# Altium schematic sheet NXP NXPhlite-MCU 3.0RC18.sch
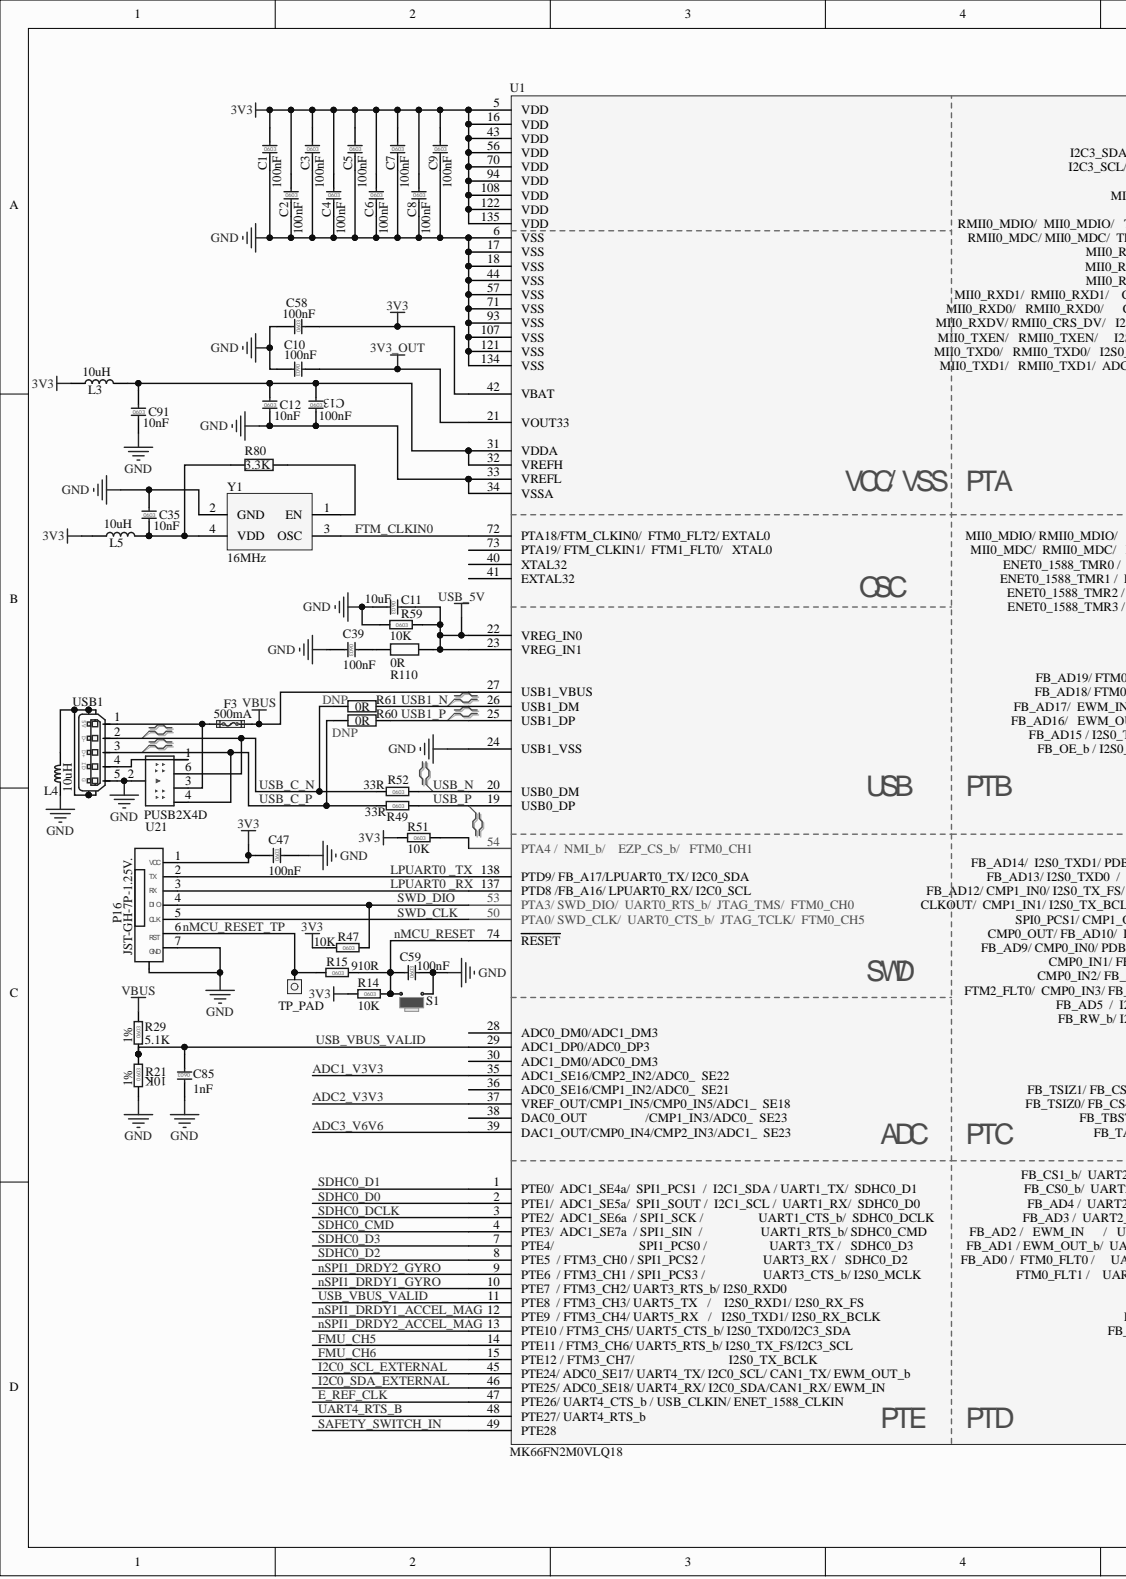
[diagram p0: NXP NXPhlite-MCU 3.0RC18.sch, left]
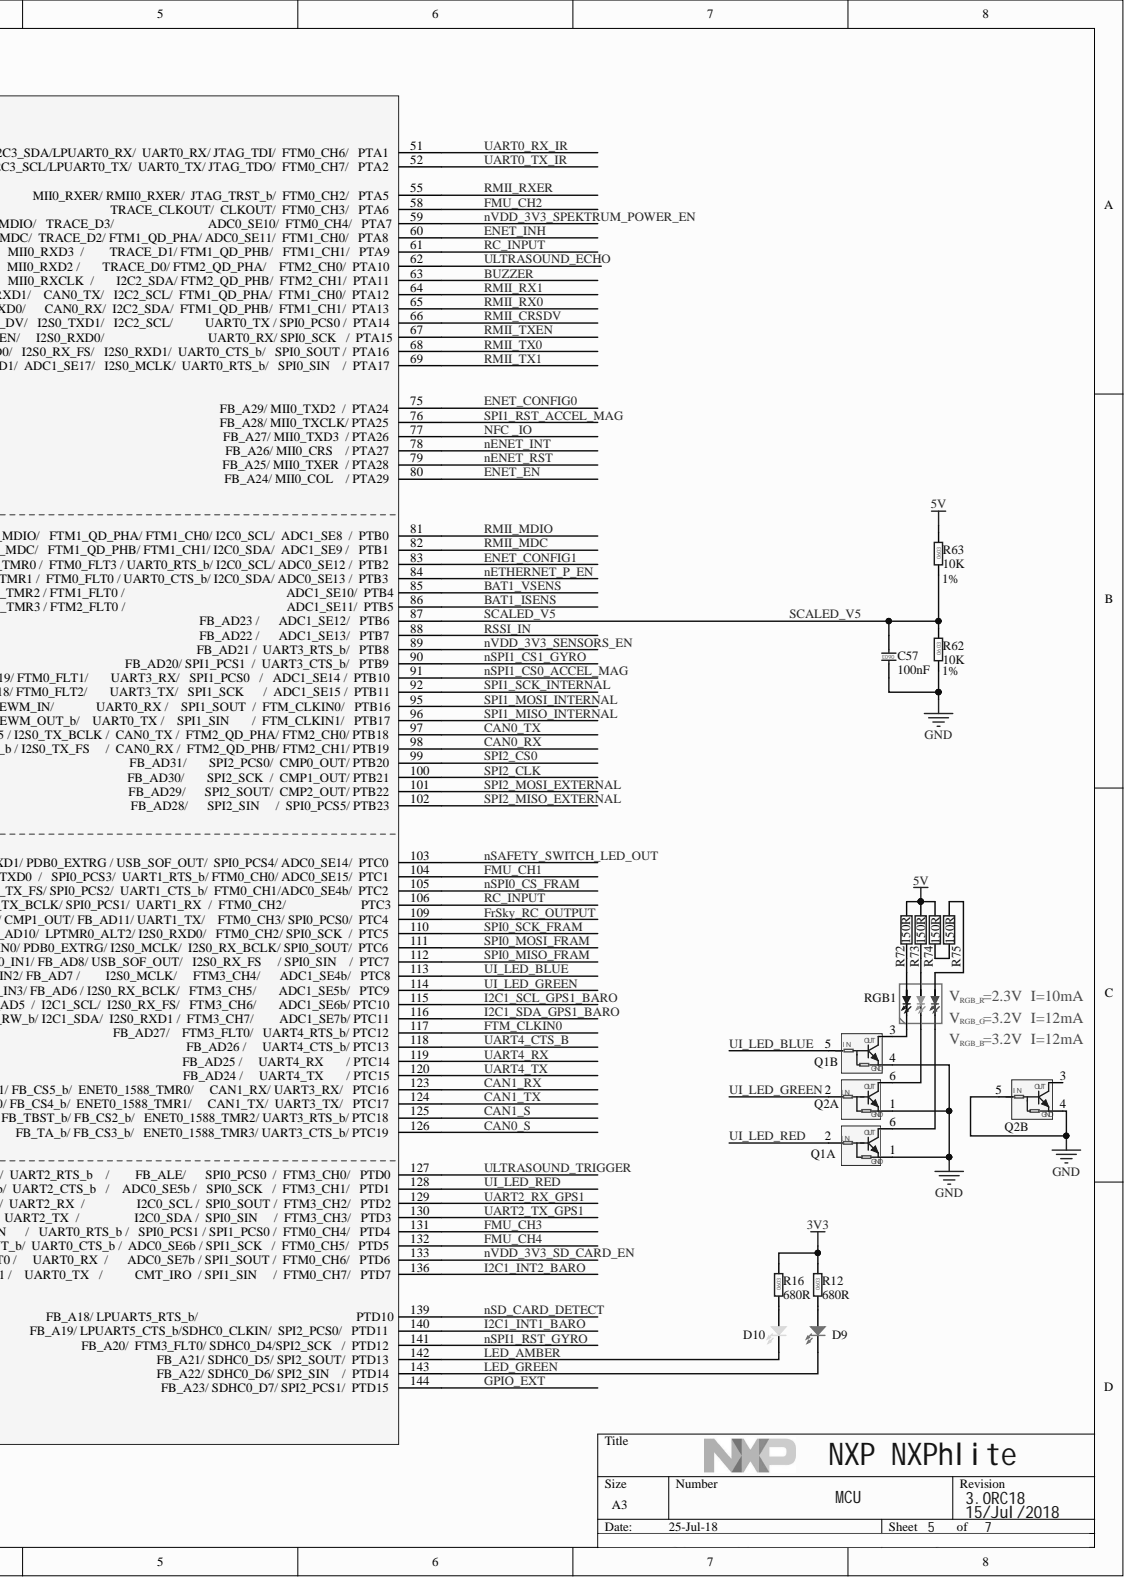
[diagram p1: NXP NXPhlite-MCU 3.0RC18.sch, right]

## Parts
C1  100nF  [0603C]
C2  100nF  [0603C]
C3  100nF  [0603C]
C4  100nF  [0603C]
C5  100nF  [0603C]
C6  100nF  [0603C]
C7  100nF  [0603C]
C8  100nF  [0603C]
C9  100nF  [0603C]
C10  100nF  [0603C]
C11  10uF  [0603C]
C12  10nF  [0603C]
C13  100nF  [0603C]
C35  10nF  [0603C]
C39  100nF  [0603C]
C47  100nF  [0603C]
C57  100nF  [0603C]
C58  100nF  [0603C]
C59  100nF  [0603C]
C85  1nF  [0603C]
C91  10nF  [0603C]
D9  LED G  [0603LED_ÂÌ]  (value not drawn)
D10  LED A  [0603LED_Amber]  (value not drawn)
F3  500mA  [0805FUSE]
L3  10uH  [0603(1608)]
L4  10uH  [0603L]
L5  10uH  [0603(1608)]
P16  JST-GH-7P-1.25V.  [JST-7P-1.25]
Q1  UMH11N  [SOT-363]  (value not drawn)  (parts A,B)
Q2  UMH11N  [SOT-363]  (value not drawn)  (parts A,B)
R12  680R  [0603R]
R14  10K  [0603R]
R15  910R  [0603R]
R16  680R  [0603R]
R21  10K  [0603R]  (value not drawn)
R29  5.1K  [0603R]
R47  10K  [0603R]
R49  33R  [0603R]
R51  10K  [0603R]
R52  33R  [0603R]
R59  10K  [0603R]
R60  0R  [0603R]
R61  0R  [0603R]
R62  10K  [0603R]
R63  10K  [0603R]
R72  150R  [0603R]
R73  150R  [0603R]
R74  150R  [0603R]
R75  150R  [0603R]
R80  3.3K  [0603R]
R110  0R  [0603R]
RGB1  5050RGB-NJ  (value not drawn)
S1  EVQP7J01K  [SW]  (value not drawn)
TP_PAD  TP  [TP_0.8]
U1  MK66FN2M0VLQ18  [LQFP144(0.5P -22X22)]
U21  PUSB2X4D  [SOT-23-6(SOT457)]
USB1  47589-0001  [USB]  (value not drawn)
Y1  16MHz  [QX333A16.00000B15M]

## Nets
3V3: C1.1 C2.1 C3.1 C4.1 C5.1 C6.1 C7.1 C8.1 C9.1 C47.1 C58.2 L3.1 L5.1 P16.1(VCC) R12.1 R14.2 R16.1 R47.2 R51.2 U1.5(VDD) U1.16(VDD) U1.42(VBAT) U1.43(VDD) U1.56(VDD) U1.70(VDD) U1.94(VDD) U1.108(VDD) U1.122(VDD) U1.135(VDD)
3V3_OUT: C10.1 U1.21(VOUT33)
5V: R63.1 R72.1 R73.1 R74.1
GND: C1.2 C2.2 C3.2 C4.2 C5.2 C6.2 C7.2 C8.2 C9.2 C10.2 C11.2 C12.2 C13.2 C35.1 C39.2 C47.2 C57.1 C58.1 C59.2 C85.1 C91.2 L4.1 P16.7 Q1.1 Q1.4 Q2.1 Q2.4 Q2.5 R21.2 R59.2 R62.2 S1.2 U1.6(VSS) U1.17(VSS) U1.18(VSS) U1.24(USB1_VSS) U1.33(VREFL) U1.34(VSSA) U1.44(VSS) U1.57(VSS) U1.71(VSS) U1.93(VSS) U1.107(VSS) U1.121(VSS) U1.134(VSS) U21.2 USB1.5 Y1.2(GND)
USB_5V: C11.1 R59.1 R110.1 U1.22(VREG_IN0) U1.23(VREG_IN1)
VBUS: F3.2 R29.1 U1.27(USB1_VBUS)
ADC1_V3V3: U1.35(ADC1_SE16/CMP2_IN2/ADC0_)
ADC2_V3V3: U1.37(VREF_OUT/CMP1_IN5/CMP0_IN5/ADC1_)
ADC3_V6V6: U1.39(DAC1_OUT/CMP0_IN4/CMP2_IN3/ADC1_)
BAT1_ISENS: U1.86(PTB5)
BAT1_VSENS: U1.85(PTB4)
BUZZER: U1.63(PTA11)
CAN0_RX: U1.98(FTM2_QD_PHB/_FTM2_CH1/_PTB19)
CAN0_S: U1.126(ENET0_1588_TMR3/_UART3_CTS_b/_PTC19)
CAN0_TX: U1.97(FTM2_QD_PHA/_FTM2_CH0/_PTB18)
CAN1_RX: U1.123(PTC16)
CAN1_S: U1.125(ENET0_1588_TMR2/_UART3_RTS_b/_PTC18)
CAN1_TX: U1.124(PTC17)
E_REF_CLK: U1.47(PTE26/_UART4_CTS_b_/_USB_CLKIN/_ENET_1588_CLKIN)
ENET_CONFIG0: U1.75(PTA24)
ENET_CONFIG1: U1.83(PTB2)
ENET_EN: U1.80(/_PTA29)
ENET_INH: U1.60(PTA8)
FMU_CH1: U1.104(PTC1)
FMU_CH2: U1.58(PTA6)
FMU_CH3: U1.131(PTD4)
FMU_CH4: U1.132(PTD5)
FMU_CH5: U1.14(PTE11_/_FTM3_CH6/_UART5_RTS_b/_I2S0_TX_FS/I2C3_SCL)
FMU_CH6: U1.15(PTE12_/_FTM3_CH7/)
FrSky_RC_OUTPUT: U1.109(PTC4)
FTM_CLKIN0: U1.72(PTA18/FTM_CLKIN0/) U1.117(UART4_RTS_b/_PTC12) Y1.3(OSC)
GPIO_EXT: U1.144(PTD15)
I2C0_SCL_EXTERNAL: U1.45(PTE24/_ADC0_SE17/_UART4_TX/_I2C0_SCL/_CAN1_TX/_EWM_OUT_b)
I2C0_SDA_EXTERNAL: U1.46(PTE25/_ADC0_SE18/_UART4_RX/_I2C0_SDA/CAN1_RX/_EWM_IN)
I2C1_INT1_BARO: U1.140(PTD11)
I2C1_INT2_BARO: U1.136(PTD7)
I2C1_SCL_GPS1_BARO: U1.115(ADC1_SE6b/_PTC10)
I2C1_SDA_GPS1_BARO: U1.116(ADC1_SE7b/_PTC11)
LED_AMBER: D10.2 U1.142(PTD13)
LED_GREEN: D9.2 U1.143(PTD14)
LPUART0 _RX: P16.3(RX) U1.137(PTD8_/FB_A16/_LPUART0_RX/_I2C0_SCL)
LPUART0 _TX: P16.2(TX) U1.138(PTD9/_FB_A17/LPUART0_TX/_I2C0_SDA)
nENET_INT: U1.78(/_PTA27)
nENET_RST: U1.79(/_PTA28)
nETHERNET_P_EN: U1.84(PTB3)
NFC _IO: U1.77(/_PTA26)
nMCU_RESET: C59.1 R14.1 R15.1 S1.1 U1.74(RESET)
nMCU_RESET_TP: P16.6 R15.2 TP_PAD.1
nSAFETY_SWITCH_LED_OUT: U1.103(PTC0)
nSD_CARD_DETECT: U1.139(PTD10)
nSPI0_CS_FRAM: U1.105(PTC2)
nSPI1_CS0_ACCEL_MAG: U1.91(PTB10)
nSPI1_CS1_GYRO: U1.90(PTB9)
nSPI1_DRDY1_ACCEL_MAG: U1.12(PTE9)
nSPI1_DRDY1_GYRO: U1.10(PTE7)
nSPI1_DRDY2_ACCEL_MAG: U1.13(PTE10_/_FTM3_CH5/_UART5_CTS_b/_I2S0_TXD0/I2C3_SDA)
nSPI1_DRDY2_GYRO: U1.9(PTE6)
nSPI1_RST_GYRO: U1.141(PTD12)
nVDD_3V3_SD_CARD_EN: U1.133(PTD6)
nVDD_3V3_SENSORS_EN: U1.89(PTB8)
nVDD_3V3_SPEKTRUM_POWER_EN: U1.59(PTA7)
RC_INPUT: U1.61(PTA9) U1.106(PTC3)
RMII_CRSDV: U1.66(PTA14)
RMII_MDC: U1.82(PTB1)
RMII_MDIO: U1.81(PTB0)
RMII_RX0: U1.65(PTA13)
RMII_RX1: U1.64(PTA12)
RMII_RXER: U1.55(PTA5)
RMII_TX0: U1.68(PTA16)
RMII_TX1: U1.69(PTA17)
RMII_TXEN: U1.67(PTA15)
RSSI_IN: U1.88(PTB7)
SAFETY_SWITCH_IN: U1.49(PTE28)
SCALED_V5: C57.2 R62.1 R63.2 U1.87(PTB6)
SDHC0_CMD: U1.4(PTE3/)
SDHC0_D0: U1.2(PTE1/)
SDHC0_D1: U1.1(PTE0/)
SDHC0_D2: U1.8(PTE5)
SDHC0_D3: U1.7(PTE4/)
SDHC0_DCLK: U1.3(PTE2/)
SPI0_MISO_FRAM: U1.112(PTC7)
SPI0_MOSI_FRAM: U1.111(PTC6)
SPI0_SCK_FRAM: U1.110(PTC5)
SPI1_MISO_INTERNAL: U1.96(PTB17)
SPI1_MOSI_INTERNAL: U1.95(PTB16)
SPI1_RST_ACCEL_MAG: U1.76(FB_A28/_MII0_TXCLK/_PTA25)
SPI1_SCK_INTERNAL: U1.92(PTB11)
SPI2_CLK: U1.100(CMP1_OUT/_PTB21)
SPI2_CS0: U1.99(CMP0_OUT/_PTB20)
SPI2_MISO_EXTERNAL: U1.102(SPI0_PCS5/_PTB23)
SPI2_MOSI_EXTERNAL: U1.101(CMP2_OUT/_PTB22)
SWD_CLK: P16.5(CLK) U1.50(PTA0/_SWD_CLK/)
SWD_DIO: P16.4(DI_O) R47.1 U1.53(PTA3/_SWD_DIO/)
UART0_RX_IR: U1.51(PTA1)
UART0_TX_IR: U1.52(PTA2)
UART2_RX_GPS1: U1.129(PTD2)
UART2_TX_GPS1: U1.130(PTD3)
UART4_CTS_B: U1.118(UART4_CTS_b/_PTC13)
UART4_RTS_B: U1.48(PTE27/_UART4_RTS_b)
UART4_RX: U1.119(/_PTC14)
UART4_TX: U1.120(/_PTC15)
UI_LED_BLUE: Q1.5 U1.113(PTC8)
UI_LED_GREEN: Q2.2 U1.114(PTC9)
UI_LED_RED: Q1.2 U1.128(PTD1)
ULTRASOUND_ECHO: U1.62(PTA10)
ULTRASOUND_TRIGGER: U1.127(PTD0)
USB1_N: R61.1 U1.26(USB1_DM)
USB1_P: R60.1 U1.25(USB1_DP)
USB_C_N: R52.2 R61.2 U21.6 USB1.2(D-)
USB_C_P: R49.2 R60.2 U21.4 USB1.3(D+)
USB_N: R52.1 U1.20(USB0_DM)
USB_P: R49.1 U1.19(USB0_DP)
USB_VBUS_VALID: C85.2 R21.1 R29.2 U1.11(PTE8) U1.29(ADC1_DP0/ADC0_DP3)
NetC12_1: C12.1 C13.1 C91.1 L3.2 U1.31(VDDA) U1.32(VREFH)
NetC35_2: C35.2 L5.2 R80.2 Y1.4(VDD)
NetC39_1: C39.1 R110.2
NetD9_1: D9.1 R12.2
NetD10_1: D10.1 R16.2
NetF3_1: F3.1 U21.3 USB1.1(5V)
NetL4_2: L4.2 USB1.6 USB1.7 USB1.8 USB1.9
NetQ1_3: Q1.3 RGB1.B-
NetQ1_6: Q1.6 RGB1.R-
NetQ2_6: Q2.6 RGB1.G-
NetR51_1: R51.1 U1.54(PTA4_/)
NetR72_2: R72.2 RGB1.B+
NetR73_2: R73.2 RGB1.G+
NetR74_2: R74.2 R75.2
NetR75_1: R75.1 RGB1.R+
NetR80_1: R80.1 Y1.1(EN)
NetU21_1: U21.1 USB1.4(ID)

Not connected: P16 0; Q2 3; U1 28(ADC0_DM0/ADC1_DM3) 30(ADC1_DM0/ADC0_DM3) 36(ADC0_SE16/CMP1_IN2/ADC0_) 38(DAC0_OUT) 40(XTAL32) 41(EXTAL32) 73(PTA19/_FTM_CLKIN1/)

## Library data of the parts
C1-C10 C13 C39 C47 C57-C59 = Cap_0603: CAP CER 0.1uF 16V 10% X7R AEC-Q200 0603; Manufacturer: MURATA; Manufacturer PN: GCM188R71C104KA37D; Agile PN: 150-79338; Component Kind: Standard; Library Name: LZ SchLib V1.0.SchLib
C11 = Cap_0603: CAP CER 10.0uF 16V 10% X5R 0603; Manufacturer: MURATA; Manufacturer PN: GRM188R61C106KAALD; Agile PN: 150-80060; Component Kind: Standard; Library Name: LZ SchLib V1.0.SchLib
C12 C35 C91 = Cap_0603: CAP CER 0.01UF 25V 5% C0G 0603; Manufacturer: TDK; Manufacturer PN: C1608C0G1E103J; Agile PN: 150-78232; Component Kind: Standard; Library Name: LZ SchLib V1.0.SchLib
C85 = Cap_0603: CAP CER 1000pF 25V 10% X7R 0603; Manufacturer: Yageo; Manufacturer PN: CC0603KRX7R8BB102; Agile PN: 150-78736; Component Kind: Standard; Library Name: LZ SchLib V1.0.SchLib
D9 = LED G: LED GRN SGL 25MA SMT 0603; Manufacturer: DIALIGHT; Manufacturer PN: 598-8070-107F; Agile PN: 370-76672; Component Kind: Standard; Library Name: LZ SchLib V1.0.SchLib
D10 = LED A: LED AMBER SGL 25MA 0603; Manufacturer: AVAGO TECHNOLOGIES; Manufacturer PN: HSMA-C190; Agile PN: 370-76574; Component Kind: Standard; Library Name: NXP NXPhlite V3.2.SCHLIB
F3 = Fuse1: FUSE FAST 0.5A 32V SMT 0805; Manufacturer: Littlelfuse; Manufacturer PN: 0805L020YR; Agile PN: TBD; Component Kind: Standard; Library Name: LZ SchLib V1.0.SchLib
L3 L5 = Inductor: FIXED IND 10UH 10MA 1.7 OHM SMD; Manufacturer: TDK; Manufacturer PN: MLF1608E100JTD25; Agile PN: TBD; Component Kind: Standard; Library Name: SchLib.SchLib
L4 = Inductor_1: FIXED IND 10UH 10MA 1.7 OHM SMD; Manufacturer: TDK; Manufacturer PN: MLF1608E100JTD25; Agile PN: TBD; Component Kind: Standard; Library Name: LZ SchLib V1.0.SchLib
P16 = DCD-MINIJST 7: CONN HEADER GH TOP 7POS 1.25MM; Manufacturer: JST Sales America Inc; Manufacturer PN: BM07B-GHS-TBT(LF)(SN)(N); Agile PN: TBD; Component Kind: Standard; Library Name: NXP NXPhlite V3.2.SCHLIB
Q1 Q2 = UMH11N: TRANS 2NPN PREBIAS 0.15W SOT363; Manufacturer: ROHM SEMICONDUCTOR; Manufacturer PN: UMH11NTN; AGILE PN: TBD; Component Kind: Standard; Library Name: NXP NXPhlite V3.2.SCHLIB
R12 R16 = Res_0603: RES MF 680 OHM 1/8W 1% AEC-Q200 0603; Manufacturer: KOA SPEER; Manufacturer PN: RK73H1JTTD6800F; Agile PN: 470-81586; Component Kind: Standard; Library Name: LZ SchLib V1.0.SchLib
R14 R21 R47 R51 R59 R62 R63 = Res_0603: RES MF 10.0K 1/10W 1% 0603; Manufacturer: Yageo; Manufacturer PN: RC0603FR-0710KL; Agile PN: 470-75422; Component Kind: Standard; Library Name: LZ SchLib V1.0.SchLib
R15 = Res_0603: RES MF 910 OHM 1/8W 1% AEC-Q200 0603; Manufacturer: KOA SPEER; Manufacturer PN: RK73H1JTTD9100F; Agile PN: 470-81610; Component Kind: Standard; Library Name: LZ SchLib V1.0.SchLib
R29 = Res_0603: RES MF 5.1K 1/10W 1% AEC-Q200 0603; Manufacturer: KOA SPEER; Manufacturer PN: RK73H1JTTD5101F; Agile PN: 470-81813; Component Kind: Standard; Library Name: LZ SchLib V1.0.SchLib
R49 R52 = Res_0603: RES MF 33 OHM 1/8W 1% AEC-Q200 0603; Manufacturer: KOA SPEER; Manufacturer PN: RK73H1JTTD33R0F; Agile Pn: 470-81926; Component Kind: Standard; Library Name: LZ SchLib V1.0.SchLib
R60 R61 = Res: Resistor; Component Kind: Standard; Library Name: SchLib.SchLib
R72-R75 = Res: RES MF 150 OHM 1/8W 1% AEC-Q200 0603; Manufacturer: KOA SPEER; Manufacturer PN: RK73H1JTTD1500F; Agile PN: 470-81446; Component Kind: Standard; Library Name: SchLib.SchLib
R80 = Res: RES MF 3.30K 1/10W 1% AEC-Q200 0603; Manufacturer: KOA SPEER; Manufacturer PN: RK73H1JTTD3301F; Agile PN: 470-81787; Component Kind: Standard; Library Name: SchLib.SchLib
R110 = Res: RES MF ZERO OHM 1/10W -- AEC-Q200 0603; Manufacturer: KOA SPEER; Manufacturer PN: RK73Z1JTTD; Agile PN: 470-75407; Component Kind: Standard; Library Name: SchLib.SchLib
RGB1 = 5050RGB-NJ: LED RGB 622NM 530NM 470NM 6SMD; Manufacturer: CREE INC; Manufacturer PN: SLV6A-FKB-CK1P1G1BB7R3R3; Agile PN: TBD; Component Kind: Standard; Library Name: NXP NXPhlite V3.2.SCHLIB
S1 = KEY1: SWITCH TACTILE SPST-NO 0.05A 12V; Manufacturer: Panasonic Electronic; Manufacturer PN: EVQ-P7J01P; Agile PN: TBD; Component Kind: Standard; Library Name: LZ插件器件V1.0.SchLib
U1 = MK64P144: IC MCU 2MB FLASH 256KB RAM 180MHZ 1.71-3.6V LQFP144; Manufacturer: NXP SEMICONDUCTORS; Agile PN: 344-02130; Component Kind: Standard; Manufacturer PN: MK66FN2M0VLQ18; Library Name: NXP FMU V2.2.SCHLIB
U21 = IP4220CZ6: TVS DIODE 4.3VC SC-74; Manufacturer: Nexperia; Manufacturer PN: PUSB2X4DH; Agile PN: TBD; Component Kind: Standard; Library Name: NXP FMU V2.2.SCHLIB
USB1 = MICRO USB-AB: CON 1X5 USB_MICRO_AB_RECEPTACLE RA SMT 0.65MM SP 105H AU; Manufacturer: Molex; Agile PN: 211-78722; Component Kind: Standard; Manufacturer PN: 47589-0001; Library Name: LZ插件器件.SchLib
Y1 = XTAL1: OSC XO 16.0MHZ 3.3V HCMOS SMD; Manufacturer: ECS Inc.; Manufacturer PN: ECS-2520S33-160-FN-TR; Agile PN: TBD; Component Kind: Standard; Library Name: SchLib.SchLib
TP_PAD = TP: Component Kind: Standard; Library Name: LZ SchLib V1.0.SchLib
